# Altium schematic sheet Schematic Prints("All Documents",Physical)
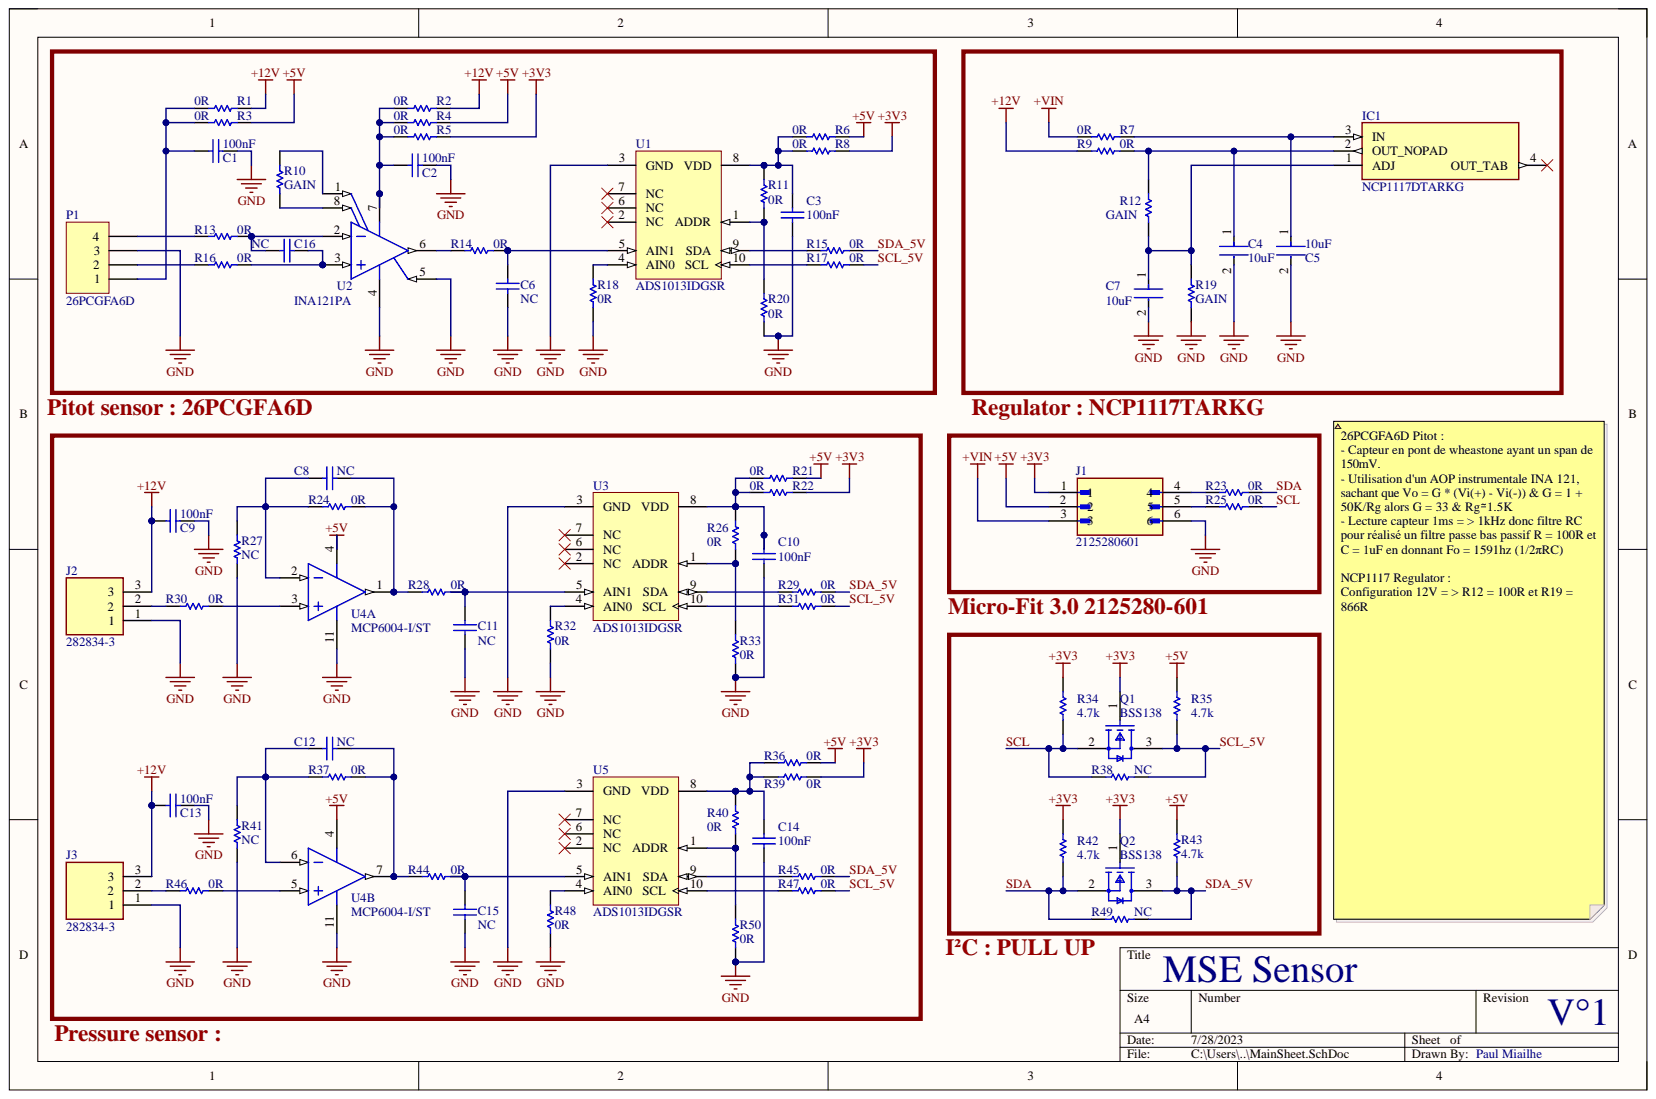
[diagram p0: Schematic Prints("All Documents",Physical)]

## Parts
C1  Capacitance: 100nF  [CAPC2013X135X45ML10T25]  (hidden comment: GRM21BR71H104KA01L)
C2  Capacitance: 100nF  [CAPC2013X135X45ML10T25]  (hidden comment: GRM21BR71H104KA01L)
C3  Capacitance: 100nF  [CAPC2013X135X45ML10T25]  (hidden comment: GRM21BR71H104KA01L)
C4  Capacitance: 10uF  [FP-GRM21B-0_15-IPC_C]  (hidden comment: GRM21BR61E106KA73L)
C5  Capacitance: 10uF  [FP-GRM21B-0_15-IPC_C]  (hidden comment: GRM21BR61E106KA73L)
C6  Capacitance: NC  [CAPC2013X135X45ML10T25]  (hidden comment: GRM21BR71H104KA01L)
C7  Capacitance: 10uF  [FP-GRM21B-0_15-IPC_C]  (hidden comment: GRM21BR61E106KA73L)
C8  Capacitance: NC  [CAPC2013X135X45ML10T25]  (hidden comment: GRM21BR71H104KA01L)
C9  Capacitance: 100nF  [CAPC2013X135X45ML10T25]  (hidden comment: GRM21BR71H104KA01L)
C10  Capacitance: 100nF  [CAPC2013X135X45ML10T25]  (hidden comment: GRM21BR71H104KA01L)
C11  Capacitance: NC  [CAPC2013X135X45ML10T25]  (hidden comment: GRM21BR71H104KA01L)
C12  Capacitance: NC  [CAPC2013X135X45ML10T25]  (hidden comment: GRM21BR71H104KA01L)
C13  Capacitance: 100nF  [CAPC2013X135X45ML10T25]  (hidden comment: GRM21BR71H104KA01L)
C14  Capacitance: 100nF  [CAPC2013X135X45ML10T25]  (hidden comment: GRM21BR71H104KA01L)
C15  Capacitance: NC  [CAPC2013X135X45ML10T25]  (hidden comment: GRM21BR71H104KA01L)
C16  Capacitance: NC  [CAPC2013X135X45ML10T25]  (hidden comment: GRM21BR71H104KA01L)
IC1  NCP1117DTARKG  [TO229P990X238-4N]
J1  2125280601  [MOLEX_2125280601]
J2  282834-3  [2828343]
J3  282834-3  [2828343]
P1  26PCGFA6D  [HDR1X4]
Q1  BSS138  [FP-318-08-IPC_B]
Q2  BSS138  [FP-318-08-IPC_B]
R1  0R  [RESC1608X55X25ML10T15]
R2  0R  [RESC1608X55X25ML10T15]
R3  0R  [RESC1608X55X25ML10T15]
R4  0R  [RESC1608X55X25ML10T15]
R5  0R  [RESC1608X55X25ML10T15]
R6  0R  [RESC1608X55X25ML10T15]
R7  0R  [RESC1608X55X25ML10T15]
R8  0R  [RESC1608X55X25ML10T15]
R9  0R  [RESC1608X55X25ML10T15]
R10  GAIN  [RESC1608X55X25ML10T15]
R11  0R  [RESC1608X55X25ML10T15]
R12  GAIN  [RESC1608X55X25ML10T15]
R13  0R  [RESC1608X55X25ML10T15]
R14  0R  [RESC1608X55X25ML10T15]
R15  0R  [RESC1608X55X25ML10T15]
R16  0R  [RESC1608X55X25ML10T15]
R17  0R  [RESC1608X55X25ML10T15]
R18  0R  [RESC1608X55X25ML10T15]
R19  GAIN  [RESC1608X55X25ML10T15]
R20  0R  [RESC1608X55X25ML10T15]
R21  0R  [RESC1608X55X25ML10T15]
R22  0R  [RESC1608X55X25ML10T15]
R23  0R  [RESC1608X55X25ML10T15]
R24  0R  [RESC1608X55X25ML10T15]
R25  0R  [RESC1608X55X25ML10T15]
R26  0R  [RESC1608X55X25ML10T15]
R27  NC  [RESC1608X55X25ML10T15]
R28  0R  [RESC1608X55X25ML10T15]
R29  0R  [RESC1608X55X25ML10T15]
R30  0R  [RESC1608X55X25ML10T15]
R31  0R  [RESC1608X55X25ML10T15]
R32  0R  [RESC1608X55X25ML10T15]
R33  0R  [RESC1608X55X25ML10T15]
R34  Resistance: 4.7k  [RESC1608X55X25LL10T15]  (hidden comment: RC0603FR-074K7L)
R35  Resistance: 4.7k  [RESC1608X55X25LL10T15]  (hidden comment: RC0603FR-074K7L)
R36  0R  [RESC1608X55X25ML10T15]
R37  0R  [RESC1608X55X25ML10T15]
R38  NC  [RESC1608X55X25ML10T15]
R39  0R  [RESC1608X55X25ML10T15]
R40  0R  [RESC1608X55X25ML10T15]
R41  NC  [RESC1608X55X25ML10T15]
R42  Resistance: 4.7k  [RESC1608X55X25LL10T15]  (hidden comment: RC0603FR-074K7L)
R43  Resistance: 4.7k  [RESC1608X55X25LL10T15]  (hidden comment: RC0603FR-074K7L)
R44  0R  [RESC1608X55X25ML10T15]
R45  0R  [RESC1608X55X25ML10T15]
R46  0R  [RESC1608X55X25ML10T15]
R47  0R  [RESC1608X55X25ML10T15]
R48  0R  [RESC1608X55X25ML10T15]
R49  NC  [RESC1608X55X25ML10T15]
R50  0R  [RESC1608X55X25ML10T15]
U1  ADS1013IDGSR  [TI-DGS10_N]
U2  INA121PA  [P0008A]
U3  ADS1013IDGSR  [TI-DGS10_N]
U4  MCP6004-I/ST  [TSSOP-ST14_M]  (parts A,B)
U5  ADS1013IDGSR  [TI-DGS10_N]

## Nets
+3V3: J1.1 Q1.1 Q2.1 R5.1 R8.2 R22.2 R34.2 R39.2 R42.2
+5V: J1.2 R3.1 R4.1 R6.2 R21.2 R35.2 R36.2 R43.2 U4.4
+12V: C9.2 C13.2 J2.3 J3.3 R1.1 R2.1 R9.1
+VIN: J1.3 R7.1
GND: C1.1 C2.1 C3.1 C4.2 C5.2 C6.2 C7.2 C9.1 C10.1 C11.2 C13.1 C14.1 C15.2 J1.6 J2.1 J3.1 P1.3 R18.1 R19.1 R20.2 R27.1 R32.1 R33.2 R41.1 R48.1 R50.2 U1.3(GND) U2.4 U2.5 U3.3(GND) U4.11 U5.3(GND)
SCL: Q1.2 R25.2 R34.1 R38.1
SCL_5V: Q1.3 R17.2 R31.2 R35.1 R38.2 R47.2
SDA: Q2.2 R23.2 R42.1 R49.1
SDA_5V: Q2.3 R15.2 R29.2 R43.1 R45.2 R49.2
NetC1_2: C1.2 P1.1 R1.2 R3.2
NetC2_2: C2.2 R2.2 R4.2 R5.2 U2.7
NetC3_2: C3.2 R6.1 R8.1 R11.1 U1.8(VDD)
NetC4_1: C4.1 IC1.2(OUT_NOPAD) R9.2 R12.1
NetC5_1: C5.1 IC1.3(IN) R7.2
NetC6_1: C6.1 R14.1 U1.5(AIN1)
NetC7_1: C7.1 IC1.1(ADJ) R12.2 R19.2
NetC8_1: C8.1 R24.1 R28.2 U4.1
NetC8_2: C8.2 R24.2 R27.2 U4.2
NetC10_2: C10.2 R21.1 R22.1 R26.1 U3.8(VDD)
NetC11_1: C11.1 R28.1 U3.5(AIN1)
NetC12_1: C12.1 R37.1 R44.2 U4.7
NetC12_2: C12.2 R37.2 R41.2 U4.6
NetC14_2: C14.2 R36.1 R39.1 R40.1 U5.8(VDD)
NetC15_1: C15.1 R44.1 U5.5(AIN1)
NetC16_1: C16.1 R13.1 U2.2
NetC16_2: C16.2 R16.1 U2.3
NetIC1_4: IC1.4(OUT_TAB)
NetJ1_4: J1.4 R23.1
NetJ1_5: J1.5 R25.1
NetJ2_2: J2.2 R30.2
NetJ3_2: J3.2 R46.2
NetP1_2: P1.2 R16.2
NetP1_4: P1.4 R13.2
NetR10_1: R10.1 U2.1
NetR10_2: R10.2 U2.8
NetR11_2: R11.2 R20.1 U1.1(ADDR)
NetR14_2: R14.2 U2.6
NetR15_1: R15.1 U1.9(SDA)
NetR17_1: R17.1 U1.10(SCL)
NetR18_2: R18.2 U1.4(AIN0)
NetR26_2: R26.2 R33.1 U3.1(ADDR)
NetR29_1: R29.1 U3.9(SDA)
NetR30_1: R30.1 U4.3
NetR31_1: R31.1 U3.10(SCL)
NetR32_2: R32.2 U3.4(AIN0)
NetR40_2: R40.2 R50.1 U5.1(ADDR)
NetR45_1: R45.1 U5.9(SDA)
NetR46_1: R46.1 U4.5
NetR47_1: R47.1 U5.10(SCL)
NetR48_2: R48.2 U5.4(AIN0)
NetU1_2: U1.2(NC)
NetU1_6: U1.6(NC)
NetU1_7: U1.7(NC)
NetU3_2: U3.2(NC)
NetU3_6: U3.6(NC)
NetU3_7: U3.7(NC)
NetU5_2: U5.2(NC)
NetU5_6: U5.6(NC)
NetU5_7: U5.7(NC)

## Library data of the parts
C1-C3 C6 C8-C16 = CMP-2100-03644-1: Chip Capacitor, 0.1 uF, +/- 10%, 50 V, -55 to 125 degC, 0805 (2012 Metric), RoHS, Tape and Reel; Manufacturer: Murata; Dielectric: X7R; Dielectric Material: Ceramic; Insulation Resistance: 10GR; Voltage: 50V; Case Code (Imperial): 0805; Case Code (Metric): 2012; Case/Package: 0805; Component Kind: Standard; Datasheet URL: http://psearch.en.murata.com/capacitor/product/GRM21BR71H104KA01%23.pdf; Datasheet Version: 42675.0; Depth: 1.25mm; Height: 1.25mm; Lead Free: LeadFree; Length: 2mm; Library Name: Altium Content Vault; Manufacturer URL: http://www.murata.com/; Material: Ceramic; Max Operating Temperature: 125degC; Min Operating Temperature: -55degC; Mount: SurfaceMount; Number of Pins: 2; Package Description: 2-Pin Surface Mount Device, Body 2 x 1.25 mm; Package Quantity: 1; Package Reference: 0805; Packaging: TapeandReel; Radiation Hardening: No; REACH SVHC: Unknown; RoHSCompliant: true; Termination: SMD/SMT; Thickness: 0.053inch; Tolerance: 10%; Voltage Rating: 50V; Voltage Rating (DC): 50V; Width: 0.049inch
C4 C5 C7 = CMP-2007-03541-2: Chip Multilayer Ceramic Capacitors for General Purpose, 0805, 10uF, X5R, 15%, 10%, 25V; Case Code (Imperial): 0805; Case Code (Metric): 2012; Case/Package: 0805; Component Kind: Standard; Depth: 1.25mm; Dielectric: X5R; Height: 1.25mm; Lead Free: Lead Free; Length: 2mm; Library Name: Altium Content Vault; Material: Ceramic; Max Operating Temperature: 85°C; Min Operating Temperature: -55°C; Mount: Surface Mount; Package Quantity: 1; Packaging: Tape and Reel; Radiation Hardening: No; Termination: SMD/SMT; Thickness: 0.053inch; Tolerance: 10%; Voltage: 25V; Voltage Rating: 25V; Voltage Rating (DC): 25V; Width: 0.049inch
IC1 = NCP1117DTARKG: Integrated Circuit; Manufacturer_Name: onsemi; Arrow Part Number: NCP1117DTARKG; Arrow Price/Stock: https://www.arrow.com/en/products/ncp1117dtarkg/on-semiconductor?region=nac; Component Kind: Standard; Datasheet Link: http://www.onsemi.com/pub/Collateral/NCP1117-D.PDF; Height: 2.38mm; Manufacturer_Part_Number: NCP1117DTARKG; Mouser Part Number: 863-NCP1117DTARKG; Mouser Price/Stock: https://www.mouser.co.uk/ProductDetail/onsemi/NCP1117DTARKG?qs=Gev%252BmEvV0iZIb8c1g2fW3A%3D%3D
J1 = CMP-013-000000-2: Connector Header Through Hole, Right Angle 6 position 0.118 (3.00mm); MF: Molex; Availability: In Stock; Check_prices: https://www.snapeda.com/parts/2125280601/Molex/view-part/?ref=eda; Component Kind: Standard; Component Type: Standard; Design Item ID: 2125280601; MP: 2125280601; Package: None; Pin Count: 6; Price: None; Purchase-URL: https://pricing.snapeda.com/search/part/2125280601/?ref=eda; SnapEDA_Link: https://www.snapeda.com/parts/2125280601/Molex/view-part/?ref=snap; Source: 2125280601.SchLib; Library Name: Saint-Étienne School of Mines- Mines Space
J2 J3 = 282834-3: Connector; Manufacturer_Name: TE Connectivity; Arrow Part Number: 282834-3; Arrow Price/Stock: https://www.arrow.com/en/products/282834-3/te-connectivity?region=europe; Component Kind: Standard; Datasheet Link: https://www.te.com/commerce/DocumentDelivery/DDEController?Action=showdoc&DocId=Customer+Drawing%7F282834%7FC1%7Fpdf%7FEnglish%7FENG_CD_282834_C1.pdf%7F282834-3; Height: 10mm; Manufacturer_Part_Number: 282834-3; Mouser Part Number: 571-2828343; Mouser Price/Stock: https://www.mouser.co.uk/ProductDetail/TE-Connectivity/282834-3?qs=A%252Bip%252BNCYi6M69kAjqV38yA%3D%3D
P1 = Header 4: Header, 4-Pin; Component Kind: Standard; LatestRevisionDate: 17-Jul-2002; LatestRevisionNote: Re-released for DXP Platform.; Library Name: Miscellaneous Connectors.IntLib; Signal Integrity: Connector; Publisher: Altium Limited
Q1 Q2 = CMP-07173-000101-1: MOSFET N-CH 50V 220MA SOT-23; Drain to Source Resistance: 3.5R; Element Configuration: Single; Fall Time: 9ns; Gate to Source Voltage (Vgs): 20V; Input Capacitance: 27pF; Max Power Dissipation: 360mW; Nominal Vgs: 1.3V; Number of Elements: 1; On-State Resistance: 6R; Turn-Off Delay Time: 20ns; Turn-On Delay Time: 2.5ns; Case/Package: SOT-23; Component Kind: Standard; Contact Plating: Tin; Continuous Drain Current (ID): 220mA; Current Rating: 220mA; Drain to Source Breakdown Voltage: 50V; Drain to Source Voltage (Vdss): 50V; Dual Supply Voltage: 50V; Height: 0.93mm; Lead Free: Lead Free; Length: 2.92mm; Library Name: Altium Content Vault; Max Operating Temperature: 150°C; Min Operating Temperature: -55°C; Mount: Surface Mount; Number of Pins: 3; Package Quantity: 1; Packaging: Tape and Reel; Power Dissipation: 360mW; Radiation Hardening: No; Rds On Max: 3.5R; REACH SVHC: No SVHC; Rise Time: 9ns; Termination: SMD/SMT; Threshold Voltage: 1.3V; Voltage Rating (DC): 50V; Weight: 0.03g; Width: 1.3mm
R1-R33 R36-R41 R44-R50 = CMP-2100-03667-1: Chip Resistor, 0 Ohm, +/- 5%, 0.1 W, -55 to 155 degC, 0603 (1608 Metric), RoHS, Tape and Reel; Manufacturer: Yageo; Power Rating: 100mW; Resistance: 0mOhm; Temperature Coefficient: 200ppm/degreesC; Voltage Rating: 50V; Case Code (Imperial): 0603; Case Code (Metric): 1608; Case/Package: 0603; Component Kind: Standard; Composition: ThickFilm; Contact Plating: Tin; Datasheet URL: http://www.yageo.com/NewPortal/yageodocoutput?fileName=/pdf/R-Chip/PYu-RC_Group_51_RoHS_L_5.pdf; Datasheet Version: Rev. 5, 10/2016; Depth: 0.8mm; Height: 0.45mm; Lead Free: LeadFree; Length: 1.6mm; Library Name: Altium Content Vault; Manufacturer URL: http://www.yageo.com/; Max Operating Temperature: 155degC; Military Standard: Not; Min Operating Temperature: -55degC; Number of Pins: 2; Package Description: 2-Pin Surface Mount Device, Body 1.6 x 0.8 mm; Package Quantity: 5000; Package Reference: 0603; Packaging: TapeandReel; Radiation Hardening: No; REACH SVHC: NoSVHC; RoHSCompliant: true; Termination: SMD/SMT; Tolerance: 5%; Voltage Rating (DC): 50V; Width: 0.8mm
R34 R35 R42 R43 = CMP-2000-06942-1: Chip Resistor, 4.7 KOhm, +/- 1%, 0.1 W, -55 to 155 degC, 0603 (1608 Metric), RoHS, Tape and Reel; Manufacturer: Yageo; Max Power Dissipation: 100mW; Temperature Coefficient: 100PPM/C; Voltage Rating: 50V; Case Code (Imperial): 0603; Case Code (Metric): 1608; Case Code - mm: 1608; Case/Package: 0603; Category: Chip SMD Resistors; Component Kind: Standard; ComponentLink1Description: https://datasheet.ciiva.com/15189/getdatasheetpartid-422754-15189760.pdf?src-supplier=Verical; ComponentLink1URL: https://datasheet.ciiva.com/15189/getdatasheetpartid-422754-15189760.pdf?src-supplier=Verical; ComponentLink2Description: https://datasheet.ciiva.com/22747/443971-22747528.pdf?src-supplier=Element14; ComponentLink2URL: https://datasheet.ciiva.com/22747/443971-22747528.pdf?src-supplier=Element14; ComponentLink3Description: https://datasheet.ciiva.com/7660/38590-7660794.pdf?src-supplier=Element14; ComponentLink3URL: https://datasheet.ciiva.com/7660/38590-7660794.pdf?src-supplier=Element14; ComponentLink4Description: https://datasheet.ciiva.com/7746/1868787-7746365.pdf?src-supplier=Element14; ComponentLink4URL: https://datasheet.ciiva.com/7746/1868787-7746365.pdf?src-supplier=Element14; ComponentLink5Description: https://datasheet.ciiva.com/46440/1868787-46440909.pdf?src-supplier=Element14; ComponentLink5URL: https://datasheet.ciiva.com/46440/1868787-46440909.pdf?src-supplier=Element14; ComponentLink6Description: https://datasheet.ciiva.com/7746/1738695-7746366.pdf?src-supplier=Element14; ComponentLink6URL: https://datasheet.ciiva.com/7746/1738695-7746366.pdf?src-supplier=Element14; Construction: Rectangular; Datasheet URL: http://www.yageo.com/documents/recent/PYu-RC_Group_51_RoHS_L_7.pdf; Datasheet Version: Rev. 7, 05/2017; Depth: 0.8mm; Features: Moisture Resistant; Height: 0.45mm; Lead Free: Lead Free; Lead Pitch: 0.3mm; Length: 1.6mm; Library Name: Altium Content Vault; Manufacturer Part Number: RC0603FR-074K7L; Manufacturer URL: http://www.yageo.com/; Max Operating Temperature: 155°C; Military Standard: Not; Min Operating Temperature: -55°C; Mounting Technology: SurfaceMount; Number of Pins: 2; Number of Terminations: 2; Operating Temperature Range: -55Cto+155C; Package Description: 2-Pin Surface Mount Device, Body 1.6 x 0.8 mm; Package Reference: 0603; Packaging: Reel; Power Rating: 100mW; Pricing 1: 1=0,10000, 10=0,02400, 50=0,02400, 100=0,01000, 1000=0,00400, 5000=0,00300, 10000=0,00300 (USD); Product: ThickFilmResistorsGeneralPurpose; REACH SVHC: No SVHC; Resistance Tolerance: 1%; Resistor Type: Thick Film; RoHS: Yes; RoHS Compliant: Yes; Series: RC; Size Code: 0603; Stock 1: 772810; Supplier 1: Mouser; Supplier Part Number 1: 603-RC0603FR-074K7L; Termination: SMD/SMT; Termination Style: SMD/SMT; Tolerance: 1%; Voltage Rating (DC): 50V; Width: 0.8mm
U1 U3 U5 = CMP-0003-00388-2: 12-Bit ADC with Integrated Oscillator, and Reference, -40 to 125 degC, 10-pin SOP (DGS10), Green (RoHS & no Sb/Br); Manufacturer: Texas Instruments; # Input Channels: 1; Analog Voltage AV/DD(Max)(V): 5.5; Analog Voltage AV/DD(Min)(V): 2; Architecture: Delta-Sigma; INL(Max)(+/-LSB): 0.5; Interface: Serial I2C; Resolution(Bits): 12; Sample Rate (max)(SPS): 3.3k; SubFamily: Precision ADC (<=10MSPS); Code_JEDEC: MO-153; Component Kind: Standard; ComponentLink1Description: Manufacturer URL; ComponentLink1URL: http://www.ti.com/; ComponentLink2Description: Datasheet; ComponentLink2URL: http://www.ti.com/general/docs/lit/getliterature.tsp?genericPartNumber=ADS1013&fileType=pdf; ComponentLink3Description: Package Specification; ComponentLink3URL: http://www.ti.com/lit/ml/mpds035b/mpds035b.pdf; DatasheetVersion: SBAS473C; Digital Supply(Max)(V): 5.5; Digital Supply(Min)(V): 2; Eco-Plan: Green (RoHS & no Sb/Br); Library Name: Altium Content Vault; Mounting Technology: Surface Mount; PackageDescription: 10-Pin Plastic Small Outline, Body 3 x 3 mm, Pitch 0.5 mm; PackageReference: DGS10; PackageVersion: 4073272/C, 02/2004; PartNumber: ADS1013IDGSR
U2 = CMP-0992-00120-2: FET-Input, Low Power Instrumentation Amplifier, -40 to 85 degC, 8-pin DIP (P8), Green (RoHS & no Sb/Br); Manufacturer: Texas Instruments; CMRR(Min)(dB): 90; Gain(V/V): 1 to 10000; Input Bias Current (+/-)(Max)(nA): 0.05; Input Offset (+/-)(Max)(uV): 1000; Input Offset Drift (+/-)(Max)(uV/Degrees Celsius): 15; Noise at 1kHz(Typ)(nV/rt(Hz)): 20; Non-Linearity (+/-)(Max)(%): 0.008; Number of Channels: 1; Output Offset (+/-)(Max)(uV): 1000/G; Output Offset Drift (+/-)(Max)(uV/Degrees Celsius): 20/G; Quiescent Current (+/-)(Typ)(mA): 0.45; SubFamily: Dual Supply; Vs(Max)(V): 36; Vs(Min)(V): 4.5; Code_JEDEC: MS-001; Component Kind: Standard; ComponentLink1Description: Manufacturer URL; ComponentLink1URL: http://www.ti.com/; ComponentLink2Description: Datasheet; ComponentLink2URL: http://www.ti.com/general/docs/lit/getliterature.tsp?genericPartNumber=INA121&fileType=pdf; ComponentLink3Description: Package Specification; ComponentLink3URL: http://www.ti.com/litv/pdf/mpdi001b; DatasheetVersion: SBOS078; Eco-Plan: Green (RoHS & no Sb/Br); Library Name: Altium Content Vault; Mounting Technology: Through Hole; PackageDescription: 8-Pin Plastic In-Line, Body 9.59 x 6.35 mm, Pitch 2.54 mm; PackageReference: P0008A; PackageVersion: 4040082/E, 04/2010; PartNumber: INA121PA
U4 = CMP-0187-00579-3: None; Amplifier Type: GENERAL PURPOSE; Bandwidth: 1MHz; Common Mode Rejection Ratio: 60dB; Frequency: 3MHz; Input Bias Current: 1pA; Input Offset Voltage (Vos): 4.5mV; Max Supply Voltage: 6V; Min Supply Voltage: 1.8V; Nominal Supply Current: 100uA; Number of Amplifiers: 4; Operating Supply Voltage: 5.5V; Output Current: 23mA; Power Supply Rejection Ratio (PSRR): 86dB; Slew Rate: 0.6V/µs; Voltage Gain: 112dB; Case/Package: TSSOP; Component Kind: Standard; Contact Plating: Tin; Gain Bandwidth Product: 1MHz; Height: 0.9mm; Input Offset Voltage-Max: 4.5mV; Lead Free: Lead Free; Length: 5mm; Library Name: Altium Content Vault; Max Operating Temperature: 85°C; Min Operating Temperature: -40°C; Mount: Surface Mount; Number of Channels: 4; Number of Circuits: 4; Number of Elements: 4; Number of Pins: 14; Package Quantity: 96; Packaging: Rail/Tube; Radiation Hardening: No; REACH SVHC: No SVHC; RoHS Compliant: Yes; Simulation: MCP6001; Slew Rate-Nom: 0.6V/µs; Supply Current: 100uA; Technology: CMOS; Unity Gain Bandwidth Product: 1MHz; Width: 4.4mm
